# Altium schematic sheet LED.SchDoc(LED)
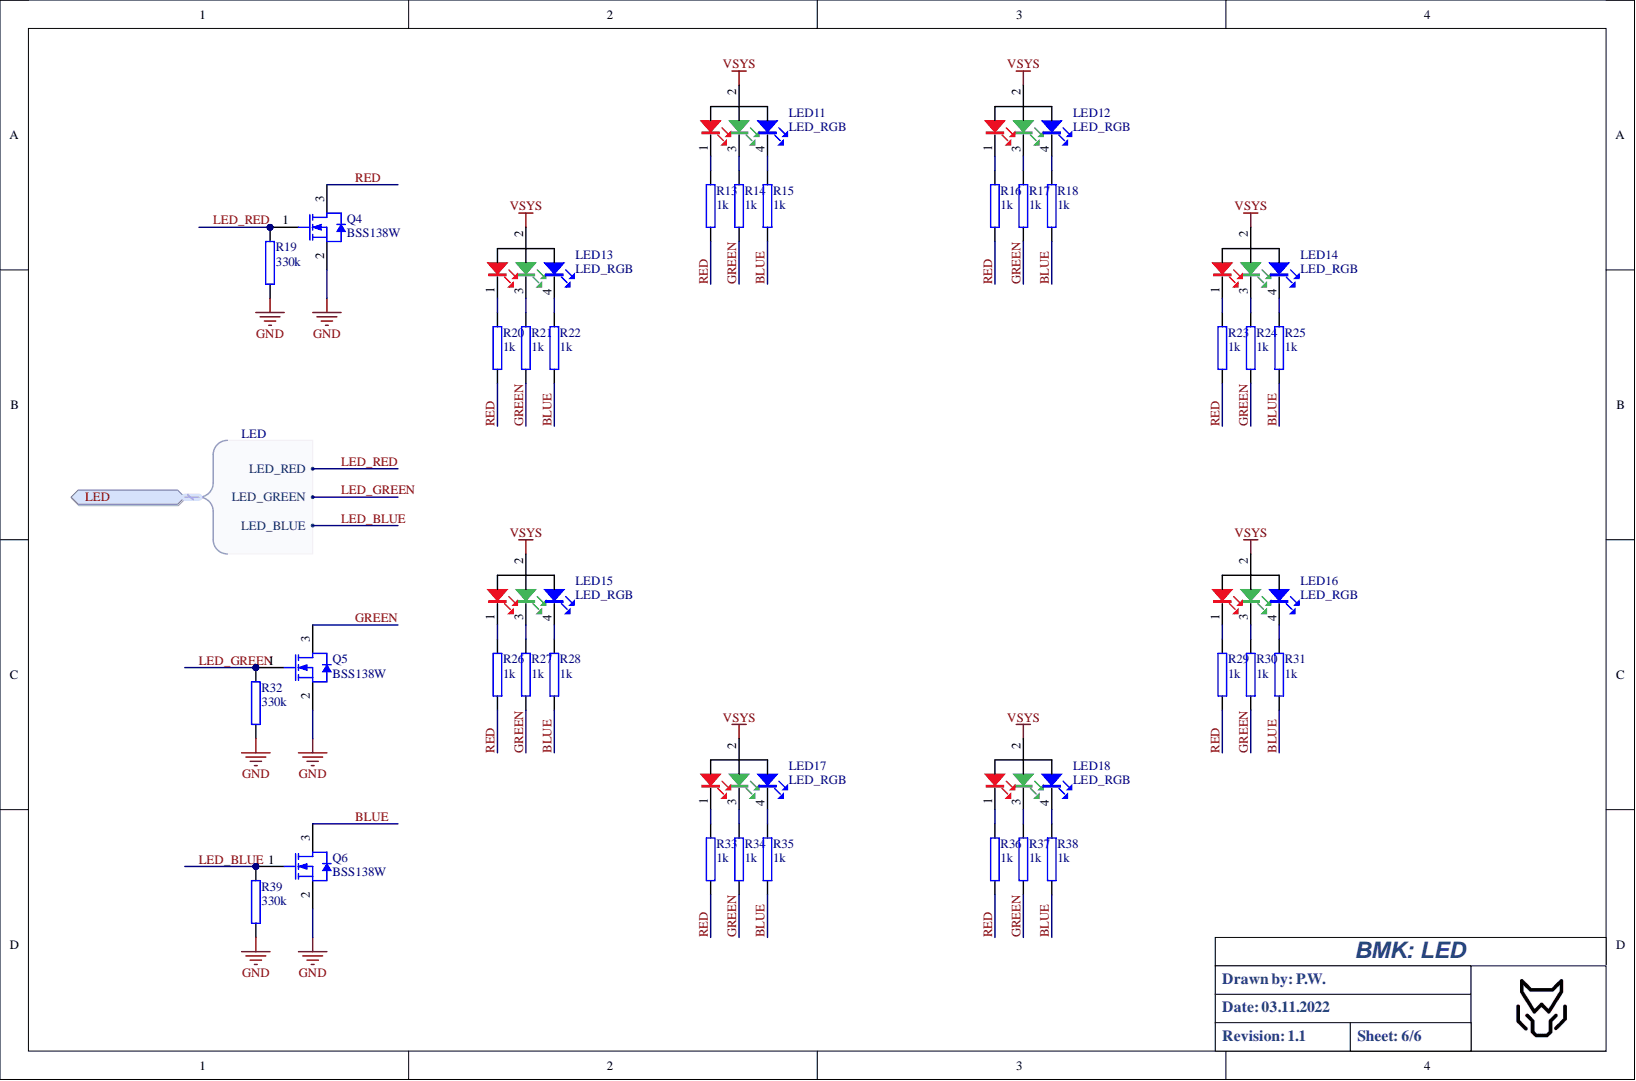
[diagram p0: LED.SchDoc]

## Parts
LED11  LED_RGB  [LED_604APFA3010LSEEZGKQ]
LED12  LED_RGB  [LED_604APFA3010LSEEZGKQ]
LED13  LED_RGB  [LED_604APFA3010LSEEZGKQ]
LED14  LED_RGB  [LED_604APFA3010LSEEZGKQ]
LED15  LED_RGB  [LED_604APFA3010LSEEZGKQ]
LED16  LED_RGB  [LED_604APFA3010LSEEZGKQ]
LED17  LED_RGB  [LED_604APFA3010LSEEZGKQ]
LED18  LED_RGB  [LED_604APFA3010LSEEZGKQ]
Q4  BSS138W  [SOT-323]
Q5  BSS138W  [SOT-323]
Q6  BSS138W  [SOT-323]
R13  1k  [1608[0603]]
R14  1k  [1608[0603]]
R15  1k  [1608[0603]]
R16  1k  [1608[0603]]
R17  1k  [1608[0603]]
R18  1k  [1608[0603]]
R19  330k  [1608[0603]]
R20  1k  [1608[0603]]
R21  1k  [1608[0603]]
R22  1k  [1608[0603]]
R23  1k  [1608[0603]]
R24  1k  [1608[0603]]
R25  1k  [1608[0603]]
R26  1k  [1608[0603]]
R27  1k  [1608[0603]]
R28  1k  [1608[0603]]
R29  1k  [1608[0603]]
R30  1k  [1608[0603]]
R31  1k  [1608[0603]]
R32  330k  [1608[0603]]
R33  1k  [1608[0603]]
R34  1k  [1608[0603]]
R35  1k  [1608[0603]]
R36  1k  [1608[0603]]
R37  1k  [1608[0603]]
R38  1k  [1608[0603]]
R39  330k  [1608[0603]]

## Ports
LED, LED.LED_BLUE, LED.LED_GREEN, LED.LED_RED

## Nets
GND: Q4.2 Q5.2 Q6.2 R19.1 R32.1 R39.1
VSYS: LED11.2 LED12.2 LED13.2 LED14.2 LED15.2 LED16.2 LED17.2 LED18.2
BLUE: Q6.3 R15.1 R18.1 R22.1 R25.1 R28.1 R31.1 R35.1 R38.1
GREEN: Q5.3 R14.1 R17.1 R21.1 R24.1 R27.1 R30.1 R34.1 R37.1
LED_BLUE: Q6.1 R39.2 ; port LED
LED_GREEN: Q5.1 R32.2 ; port LED
LED_RED: Q4.1 R19.2 ; port LED
RED: Q4.3 R13.1 R16.1 R20.1 R23.1 R26.1 R29.1 R33.1 R36.1
NetLED11_1: LED11.1 R13.2
NetLED11_3: LED11.3 R14.2
NetLED11_4: LED11.4 R15.2
NetLED12_1: LED12.1 R16.2
NetLED12_3: LED12.3 R17.2
NetLED12_4: LED12.4 R18.2
NetLED13_1: LED13.1 R20.2
NetLED13_3: LED13.3 R21.2
NetLED13_4: LED13.4 R22.2
NetLED14_1: LED14.1 R23.2
NetLED14_3: LED14.3 R24.2
NetLED14_4: LED14.4 R25.2
NetLED15_1: LED15.1 R26.2
NetLED15_3: LED15.3 R27.2
NetLED15_4: LED15.4 R28.2
NetLED16_1: LED16.1 R29.2
NetLED16_3: LED16.3 R30.2
NetLED16_4: LED16.4 R31.2
NetLED17_1: LED17.1 R33.2
NetLED17_3: LED17.3 R34.2
NetLED17_4: LED17.4 R35.2
NetLED18_1: LED18.1 R36.2
NetLED18_3: LED18.3 R37.2
NetLED18_4: LED18.4 R38.2

## Library data of the parts
LED11-LED18 = LED_RGB_2: Red/green/blue LED; Component Kind: Standard; Library Name: LIB_PW.IntLib
Q4-Q6 = MOSFET N: Component Kind: Standard; Library Name: LIB_PW.IntLib
R13-R39 = RES: Component Kind: Standard; Library Name: LIB_PW.IntLib
